# Altium schematic sheet power.SchDoc
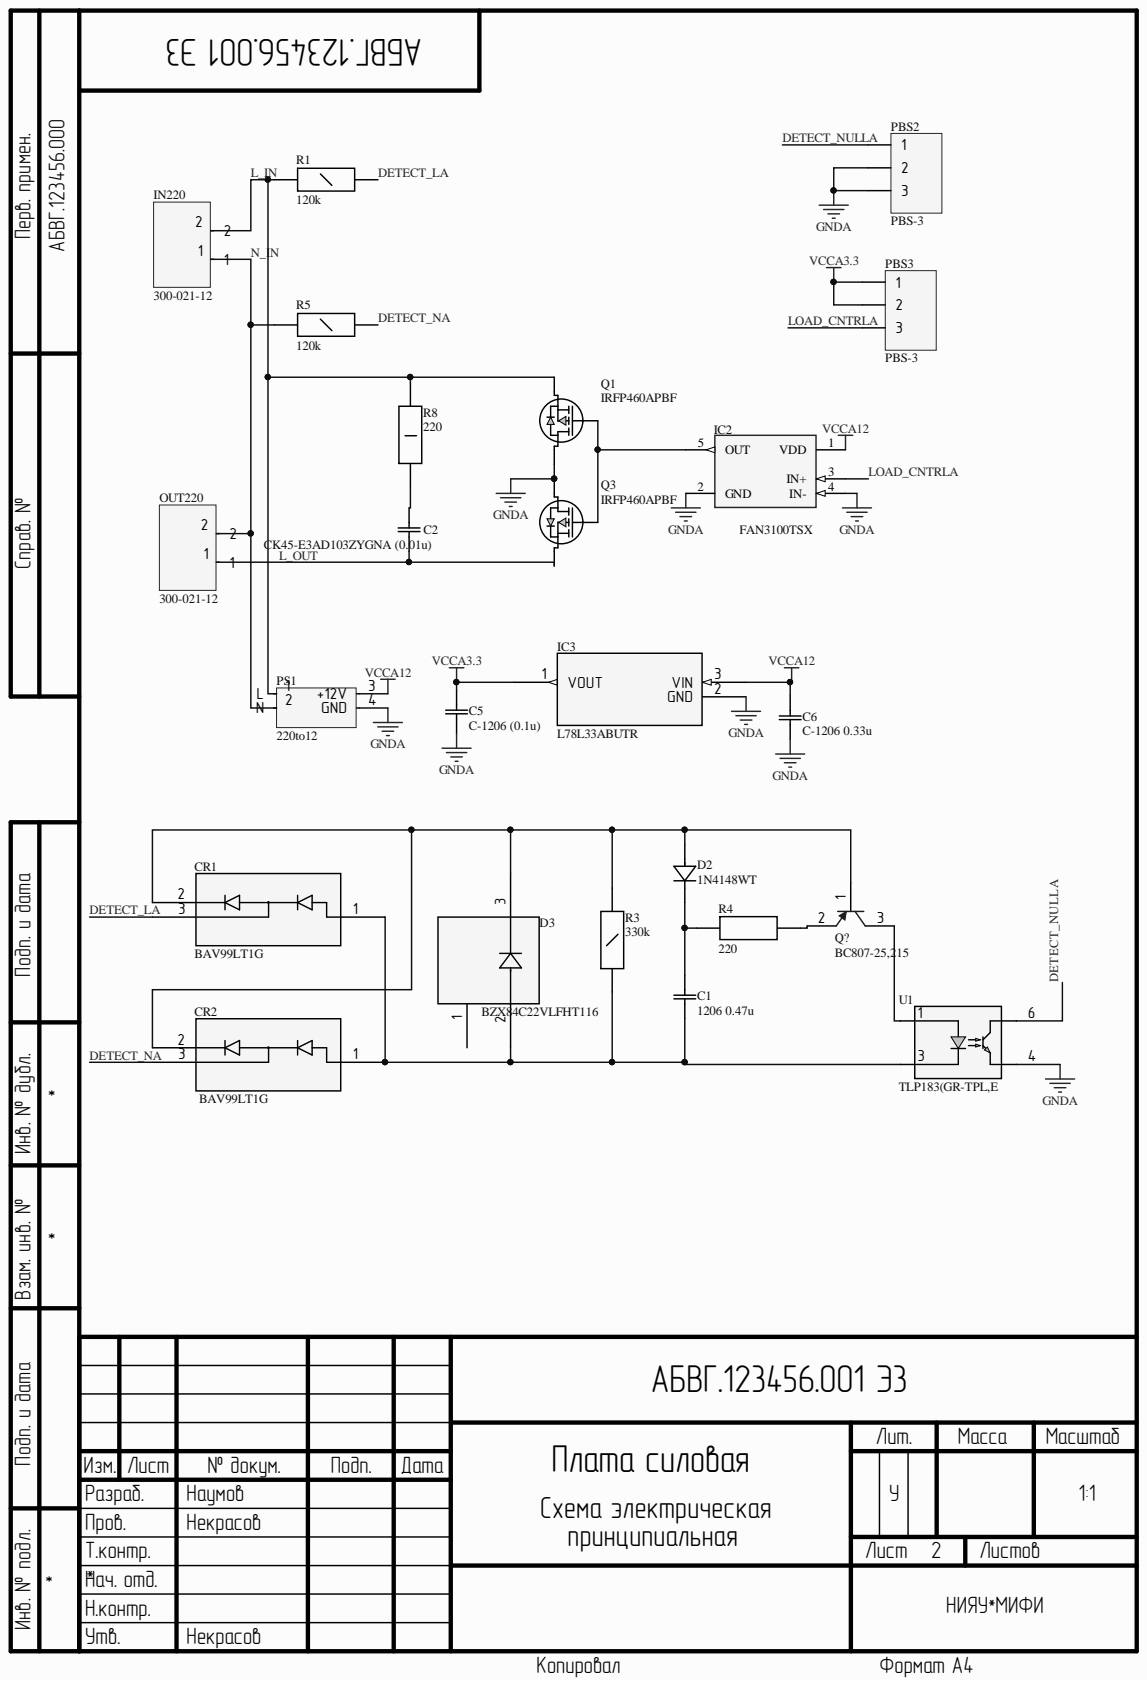
[diagram p0: power.SchDoc]

## Parts
C1  1206 0.47u  [CAP_X7R_1206_AVX]
C2  CK45-E3AD103ZYGNA (0.01u)  [CAP_CK45E3103_TDK]
C5  C-1206 (0.1u)  [CAP_X7R_1206_AVX]
C6  C-1206 0.33u  [CAP_X7R_1206_AVX]
CR1  BAV99LT1G  [SOT-23_TO-236_318-08_ONS]
CR2  BAV99LT1G  [SOT-23_TO-236_318-08_ONS]
D2  1N4148WT  [CR_SOD-523F_ONS]
D3  BZX84C22VLFHT116  [TRANS_BZX84_ROM]
IC2  FAN3100TSX  [ON_Semi_527AH_0]
IC3  L78L33ABUTR  [SOT-89_STM]
IN220  300-021-12  [300-021-12]
OUT220  300-021-12  [300-021-12]
PBS2  PBS-3  [PBS-3]
PBS3  PBS-3  [PBS-3]
PS1  220to12  [220to12]
Q1  IRFP460APBF  [TO-247AC_VIS]
Q3  IRFP460APBF  [TO-247AC_VIS]
Q?  BC807-25,215  [SOT23_BC807_NEX]
R1  120k  [CAP_X7R_1206_AVX]
R3  330k  [CAP_X7R_1206_AVX]
R4  220  [G-21_MUR]
R5  120k  [CAP_X7R_1206_AVX]
R8  220  [RES2512_TL_TYCO_TYC]
U1  TLP183(GR-TPL,E  [4pin SO6_TOS]

## Nets
GNDA: C5.1 C6.1 IC2.2(GND) IC2.4(IN-) IC3.2 PBS2.2 PBS2.3 PS1.4 Q1.2 Q3.2 U1.4
VCCA3.3: C5.2 IC3.1 PBS3.1 PBS3.2
VCCA12: C6.2 IC2.1(VDD) IC3.3 PS1.3
DETECT_LA: CR1.3 R1.2
DETECT_NA: CR2.3 R5.2
DETECT_NULLA: PBS2.1 U1.6
L_IN: IN220.2 PS1.1 Q1.3 R1.1 R8.2
L_OUT: C2.1 OUT220.1 Q3.3
LOAD_CNTRLA: IC2.3(IN+) PBS3.3
N_IN: IN220.1 OUT220.2 PS1.2 R5.1
NetC1_1: C1.1 CR1.1 CR2.1 D3.2 R3.1 U1.3
NetC1_2: C1.2 D2.1 R4.1
NetC2_2: C2.2 R8.1
NetCR1_2: CR1.2 CR2.2 D2.2 D3.3 Q?.1 R3.2
NetD3_1: D3.1
NetIC2_5: IC2.5(OUT) Q1.1 Q3.1
NetQ?_2: Q?.2 R4.2
NetQ?_3: Q?.3 U1.1

## Library data of the parts
C1 C5 C6 = C-1206: No Description Available; Manufacturer_Part_Number: 12061C104KAT2A; Built_By: EMA_UL_Team; SourceLibrary: AVX_2012-08-08; Type: DEV; Vendor: AVX Corporation; Component Kind: Standard; RefDes: RefDes; Copyright: Copyright (C) 2018 Accelerated Designs. All rights reserved; Library Name: diplom.IntLib
C2 = CK45-E3AD103ZYGNA: No Description Available; Built_By: EMA_Matt; SourceLibrary: TDK_2019-10-09; Type: DEV; Vendor: TDK; Component Kind: Standard; Manufacturer_Part_Number: CK45-E3AD103ZYGNA; RefDes: RefDes; Copyright: Copyright (C) 2018 Accelerated Designs. All rights reserved; Library Name: diplom.IntLib
CR1 CR2 = BAV99LT1G: No Description Available; Built_By: EMA_Cory; SourceLibrary: ON_Semiconductor_2020-05-27; Type: DEV; Vendor: ON Semiconductor; Component Kind: Standard; Datasheet: https://www.onsemi.com/pub/Collateral/BAV99LT1-D.PDF; Manufacturer_Part_Number: BAV99LT1G; RefDes: RefDes; Copyright: Copyright (C) 2018 Accelerated Designs. All rights reserved; Library Name: diplom.IntLib
D2 = 1N4148WT: No Description Available; Built_By: EMA_Cory; SourceLibrary: ON_Semiconductor_2020-03-23; Type: DEV; Vendor: ON Semiconductor; Component Kind: Standard; Datasheet: https://www.onsemi.com/pub/Collateral/1N914BWT-D.pdf; Manufacturer_Part_Number: 1N4148WT; RefDes: RefDes; Copyright: Copyright (C) 2018 Accelerated Designs. All rights reserved; Library Name: diplom.IntLib
D3 = BZX84C22VLFHT116: No Description Available; Built_By: EMA_Matt; SourceLibrary: Rohm_2019-09-23; Type: DEV; Vendor: ROHM Semiconductor; Component Kind: Standard; Manufacturer_Part_Number: BZX84C22VLFHT116; RefDes: RefDes; Copyright: Copyright (C) 2018 Accelerated Designs. All rights reserved; Library Name: diplom.IntLib
IC3 = L78L33ABUTR: No Description Available; Built_By: EMA_UL_Team; SourceLibrary: StMicro_2011-06-07; Vendor: STMicroelectronics; Component Kind: Standard; Manufacturer_Part_Number: L78L33ABUTR; RefDes: RefDes; Type: DEV; Copyright: Copyright (C) 2018 Accelerated Designs. All rights reserved; Library Name: diplom.IntLib
Q1 Q3 = IRFP460APBF: No Description Available; Built_By: EMA_UL_Team; SourceLibrary: Vishay_2020-02-10; Type: DEV; Vendor: Vishay; Component Kind: Standard; Manufacturer_Part_Number: IRFP460APBF; RefDes: RefDes; Copyright: Copyright (C) 2018 Accelerated Designs. All rights reserved; Library Name: diplom.IntLib
Q? = BC807-25,215: No Description Available; Built_By: EMA_Justin; SourceLibrary: Nexperia_2018-12-15; Type: DEV; Vendor: Nexperia; Component Kind: Standard; Manufacturer_Part_Number: BC807-25,215; RefDes: RefDes; Copyright: Copyright (C) 2016 Accelerated Designs. All rights reserved; Library Name: diplom.IntLib
R1 R3 R5 = R-1206: Component Kind: Standard; Description: R-1206; Library Name: diplom.IntLib
R4 = res0805: Resistor 0805; Component Kind: Standard; Library Name: diplom.IntLib
R8 = R-2512: No Description Available; Manufacturer_Part_Number: TL3AR033F; Built_By: EMA_UL_Team; SourceLibrary: Tyco_2012-01-31a; Vendor: TE Connectivity; Component Kind: Standard; RefDes: RefDes; Type: DEV; Copyright: Copyright (C) 2018 Accelerated Designs. All rights reserved; Library Name: diplom.IntLib
U1 = TLP183(GR-TPL,E: No Description Available; Built_By: EMA_UL_Team; Number_devices_in_Package: 1; SourceLibrary: Toshiba_2019-12-10; Vendor: Toshiba; Component Kind: Standard; Manufacturer_Part_Number: TLP183(GR-TPL,E; RefDes: RefDes; Source_Package: TLP183; Type: DEV; Copyright: Copyright (C) 2018 Accelerated Designs. All rights reserved; Library Name: diplom.IntLib
IC2 = FAN3100TSX: Component Kind: Standard; Library Name: diplom.IntLib
IN220 OUT220 = 300-021-12: Component Kind: Standard; Library Name: diplom.IntLib
PBS2 PBS3 = PBS-3: Component Kind: Standard; Library Name: diplom.IntLib
PS1 = 220to12: Component Kind: Standard; Library Name: diplom.IntLib
